# Altium schematic sheet MCU.SchDoc(MCU)
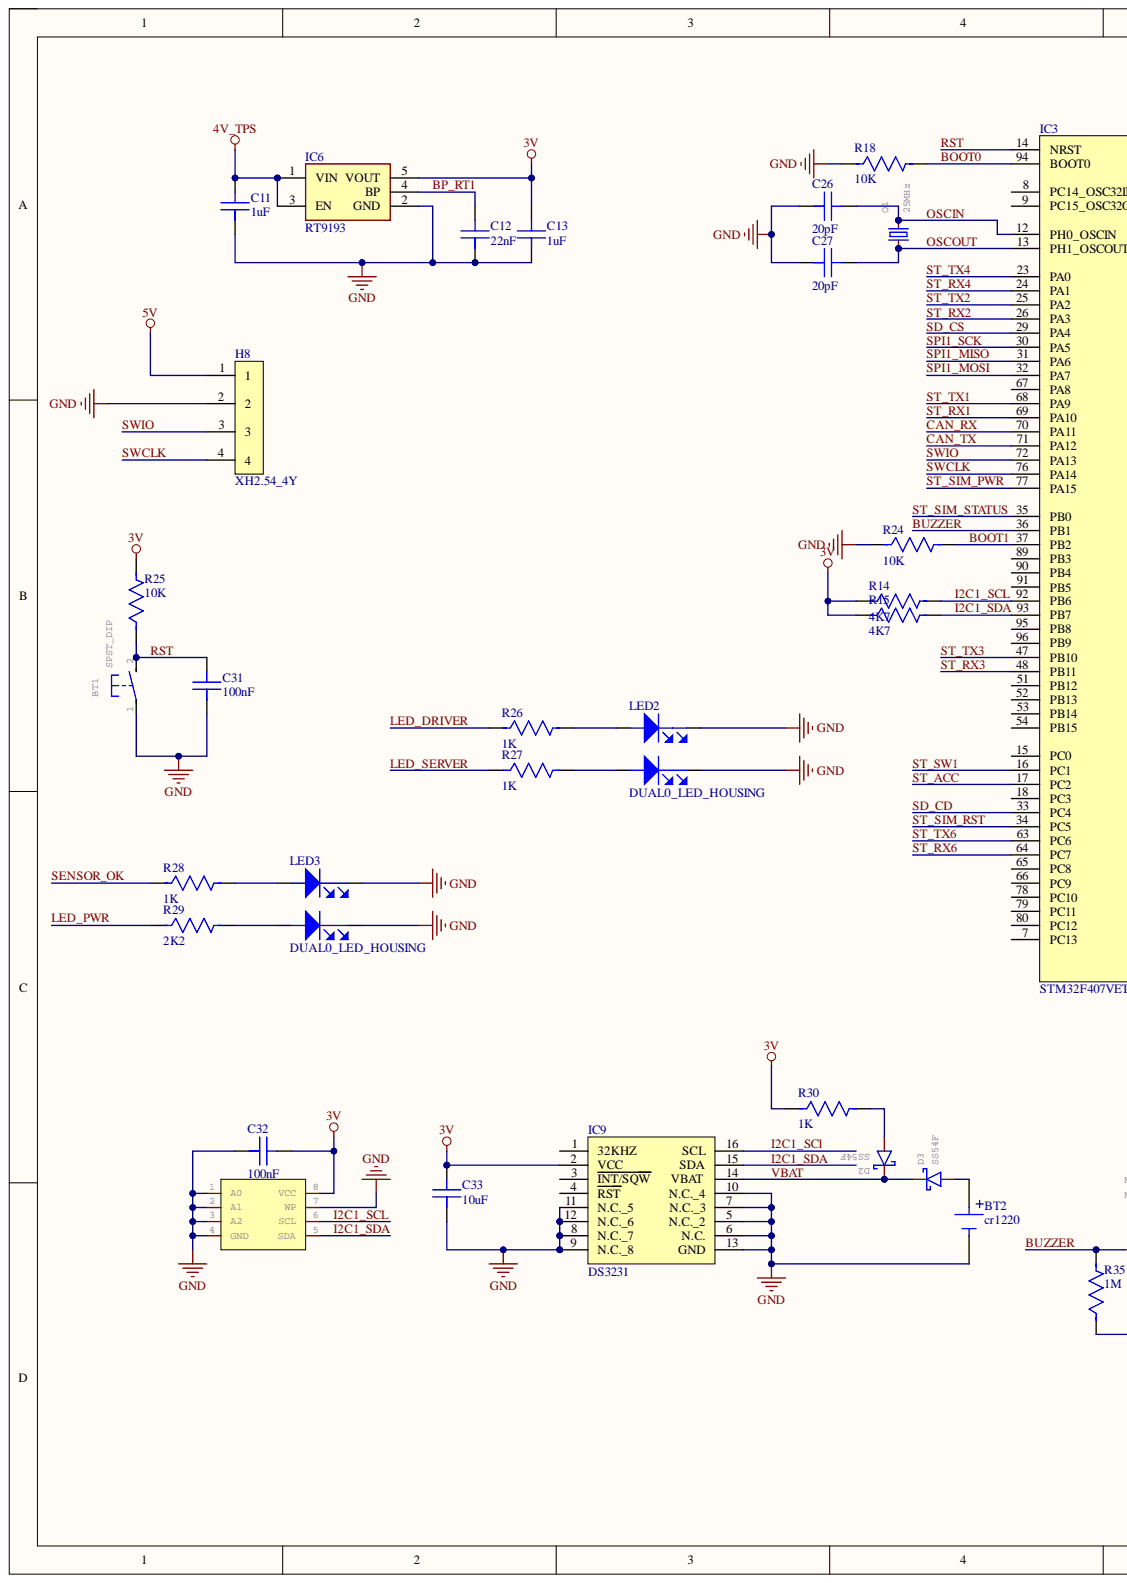
[diagram p0: MCU.SchDoc, left]
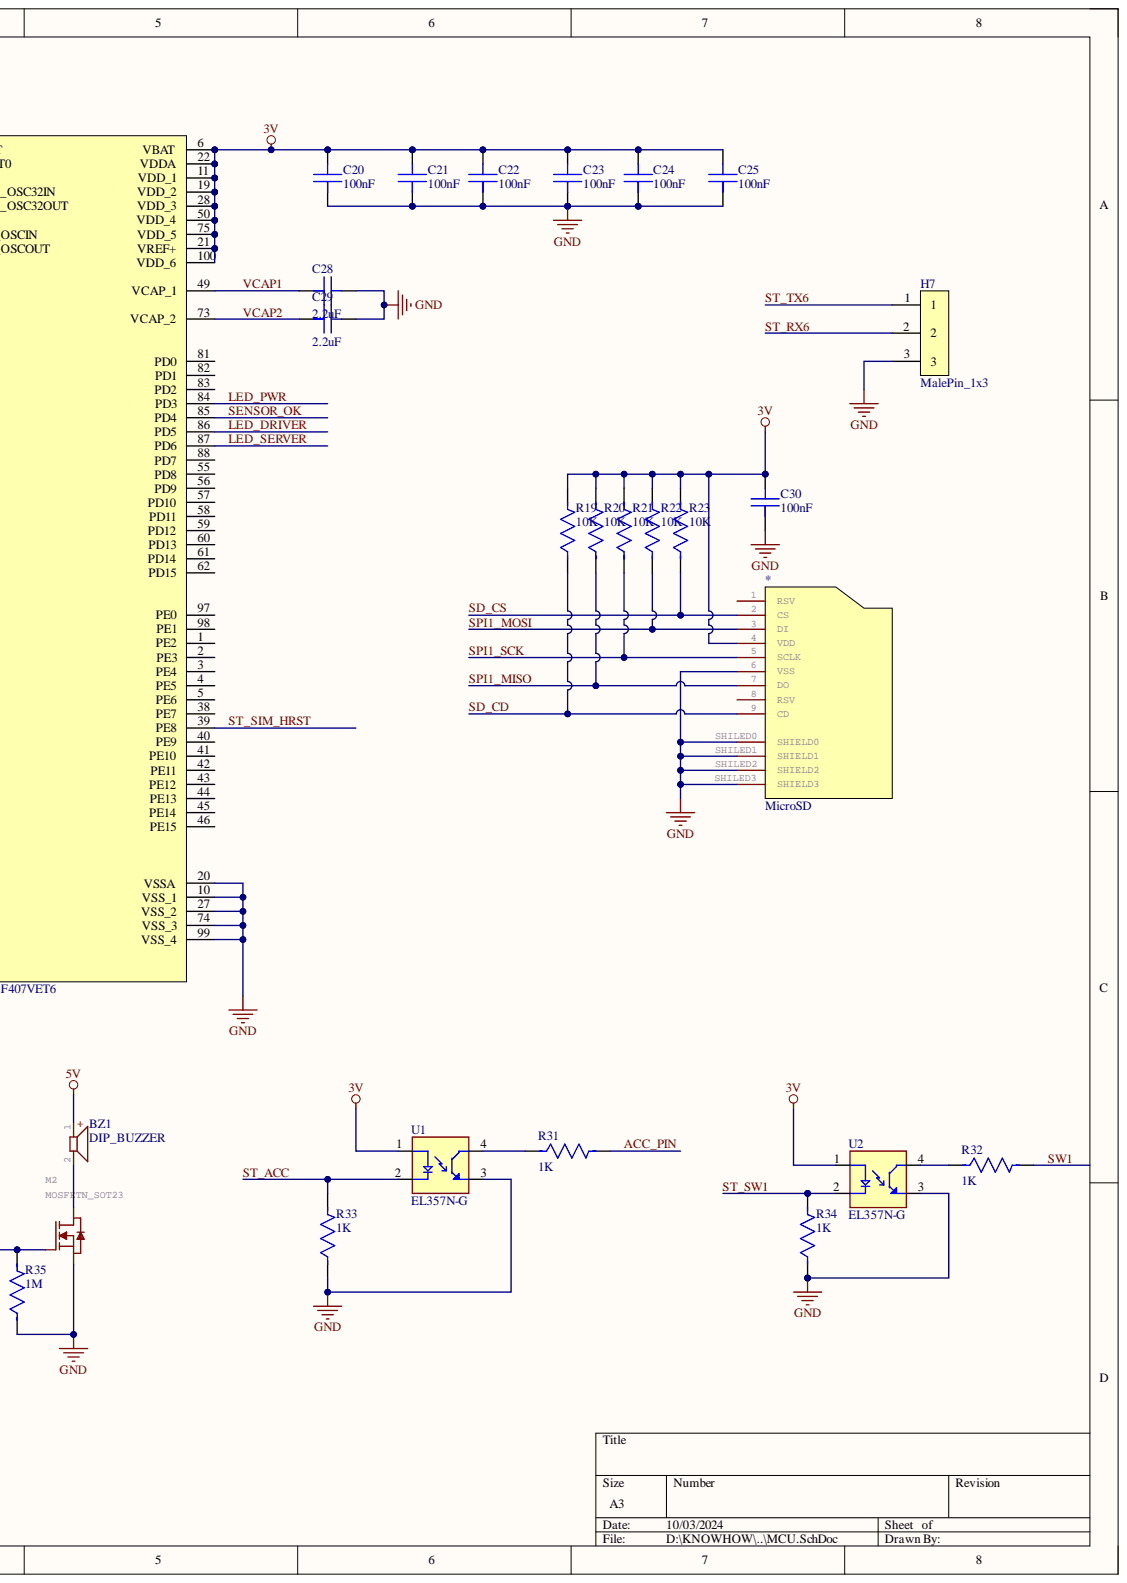
[diagram p1: MCU.SchDoc, right]

## Parts
*  MicroSD  [SD_MiSD-PP-9P-V1]
BT1  SPST_DIP  SymbolName_1: BT1  [SPST_BUTTON_2DIP]
BT2  cr1220  [cr1220]
BZ1  DIP_BUZZER  [DIP_BUZZER]
C11  1uF  [C0603]
C12  22nF  [C0603]
C13  1uF  [C0603]
C20  100nF  [C0603]
C21  100nF  [C0603]
C22  100nF  [C0603]
C23  100nF  [C0603]
C24  100nF  [C0603]
C25  100nF  [C0603]
C26  20pF  [C0603]
C27  20pF  [C0603]
C28  2.2uF  [C0603]
C29  2.2uF  [C0805]
C30  100nF  [C0603]
C31  100nF  [C0603]
C32  100nF  [C0603]
C33  10uF  [C0603]
D2  SS54F  [SMAF]
D3  SS54F  [SMAF]
H7  MalePin_1x3  [MALEPIN_1x3]
H8  XH2.54_4Y  [JST-XH-04-PACKAGE-LONG-PAD]
IC3  STM32F407VET6  [LQFP100]
IC6  RT9193  [SOT-23-5]
IC9  DS3231  [SOP-16]
IC10  24LC0XX  [SOIC-8]  (value not drawn)
LED2  DUAL0_LED_HOUSING  [DUAL LED HOUSING]
LED3  DUAL0_LED_HOUSING  [DUAL LED HOUSING]
M2  MOSFETN_SOT23  [SOT23]
O1  25MHz  [CRYSTAL_5032]
R14  4K7  [R0603]
R15  4K7  [R0603]
R18  10K  [R0603]
R19  10K  [R0603]
R20  10K  [R0603]
R21  10K  [R0603]
R22  10K  [R0603]
R23  10K  [R0603]
R24  10K  [R0603]
R25  10K  [R0603]
R26  1K  [R0603]
R27  1K  [R0603]
R28  1K  [R0603]
R29  2K2  [R0603]
R30  1K  [R0603]
R31  1K  [R0603]
R32  1K  [R0603]
R33  1K  [R0603]
R34  1K  [R0603]
R35  1M  [R0603]
U1  EL357N-G  [OPTO_EL357N-G]
U2  EL357N-G  [OPTO_EL357N-G]

## Nets
3V: *.4(VDD) C13.2 C20.2 C21.2 C22.2 C23.2 C24.2 C25.2 C30.2 C32.2 C33.2 IC3.6(VBAT) IC3.11(VDD_1) IC3.19(VDD_2) IC3.21(VREF+) IC3.22(VDDA) IC3.28(VDD_3) IC3.50(VDD_4) IC3.75(VDD_5) IC3.100(VDD_6) IC6.5(VOUT) IC9.2(VCC) IC10.8(VCC) R14.1 R15.1 R19.2 R20.2 R21.2 R22.2 R23.2 R25.2 R30.1 U1.1 U2.1
4V_TPS: C11.2 IC6.1(VIN) IC6.3(EN)
5V: BZ1.1 H8.1
GND: *.6(VSS) *.SHILED0(SHIELD0) *.SHILED1(SHIELD1) *.SHILED2(SHIELD2) *.SHILED3(SHIELD3) BT1.1 BT2.N C11.1 C12.1 C13.1 C20.1 C21.1 C22.1 C23.1 C24.1 C25.1 C26.1 C27.1 C28.2 C29.2 C30.1 C31.1 C32.1 C33.1 H7.3 H8.2 IC3.10(VSS_1) IC3.20(VSSA) IC3.27(VSS_2) IC3.74(VSS_3) IC3.99(VSS_4) IC6.2(GND) IC9.5(N.C._2) IC9.6(N.C.) IC9.7(N.C._3) IC9.8(N.C._7) IC9.9(N.C._8) IC9.10(N.C._4) IC9.11(N.C._5) IC9.12(N.C._6) IC9.13(GND) IC10.1(A0) IC10.2(A1) IC10.3(A2) IC10.4(GND) IC10.7(WP) LED2.2 LED2.4 LED3.2 LED3.4 M2.2 R18.1 R24.1 R33.1 R34.1 R35.1 U1.3 U2.3
ACC_PIN: R31.2
BOOT0: IC3.94(BOOT0) R18.2
BOOT1: IC3.37(PB2) R24.2
BP_RT1: C12.2 IC6.4(BP)
BUZZER: IC3.36(PB1) M2.1 R35.2
CAN_RX: IC3.70(PA11)
CAN_TX: IC3.71(PA12)
I2C1_SCl: IC3.92(PB6) IC9.16(SCL) IC10.6(SCL) R14.2
I2C1_SDA: IC3.93(PB7) IC9.15(SDA) IC10.5(SDA) R15.2
LED_DRIVER: IC3.86(PD5) R26.1
LED_PWR: IC3.84(PD3) R29.1
LED_SERVER: IC3.87(PD6) R27.1
OSCIN: C26.2 IC3.12(PH0_OSCIN) O1.2
OSCOUT: C27.2 IC3.13(PH1_OSCOUT) O1.1
RST: BT1.2 C31.2 IC3.14(NRST) R25.1
SD_CD: *.9(CD) IC3.33(PC4) R19.1
SD_CS: *.2(CS) IC3.29(PA4) R23.1
SENSOR_OK: IC3.85(PD4) R28.1
SPI1_MISO: *.7(DO) IC3.31(PA6) R20.1
SPI1_MOSI: *.3(DI) IC3.32(PA7) R22.1
SPI1_SCK: *.5(SCLK) IC3.30(PA5) R21.1
ST_ACC: IC3.17(PC2) R33.2 U1.2
ST_RX1: IC3.69(PA10)
ST_RX2: IC3.26(PA3)
ST_RX3: IC3.48(PB11)
ST_RX4: IC3.24(PA1)
ST_RX6: H7.2 IC3.64(PC7)
ST_SIM_HRST: IC3.39(PE8)
ST_SIM_PWR: IC3.77(PA15)
ST_SIM_RST: IC3.34(PC5)
ST_SIM_STATUS: IC3.35(PB0)
ST_SW1: IC3.16(PC1) R34.2 U2.2
ST_TX1: IC3.68(PA9)
ST_TX2: IC3.25(PA2)
ST_TX3: IC3.47(PB10)
ST_TX4: IC3.23(PA0)
ST_TX6: H7.1 IC3.63(PC6)
SW1: R32.2
SWCLK: H8.4 IC3.76(PA14)
SWIO: H8.3 IC3.72(PA13)
VBAT: D2.C D3.C IC9.14(VBAT)
VCAP1: C28.1 IC3.49(VCAP_1)
VCAP2: C29.1 IC3.73(VCAP_2)
NetBT2_P: BT2.P D3.A
NetBZ1_2: BZ1.2 M2.3
NetD2_A: D2.A R30.2
NetLED2_1: LED2.1 R26.2
NetLED2_3: LED2.3 R27.2
NetLED3_1: LED3.1 R28.2
NetLED3_3: LED3.3 R29.2
NetR31_1: R31.1 U1.4
NetR32_1: R32.1 U2.4

Not connected: * 1(RSV) 8(RSV); IC3 1(PE2) 2(PE3) 3(PE4) 4(PE5) 5(PE6) 7(PC13) 8(PC14_OSC32IN) 9(PC15_OSC32OUT) 15(PC0) 18(PC3) 38(PE7) 40(PE9) 41(PE10) 42(PE11) 43(PE12) 44(PE13) 45(PE14) 46(PE15) 51(PB12) 52(PB13) 53(PB14) 54(PB15) 55(PD8) 56(PD9) 57(PD10) 58(PD11) 59(PD12) 60(PD13) 61(PD14) 62(PD15) 65(PC8) 66(PC9) 67(PA8) 78(PC10) 79(PC11) 80(PC12) 81(PD0) 82(PD1) 83(PD2) 88(PD7) 89(PB3) 90(PB4) 91(PB5) 95(PB8) 96(PB9) 97(PE0) 98(PE1); IC9 1(32KHZ) 3(INT/SQW) 4(RST)

## Library data of the parts
BT1 = SPST_DIP: DeviceSetName: BT4; GateName_1: G$1; Component Kind: Standard; LibraryName: Uy_SMD; Package: BT4; Library Name: UY_Schlib.SchLib
BT2 = cr1220: BATTERY HOLDER COIN 12MM SMD; MF: MPD; MP: BC501SM; Availability: Unavailable; Check_prices: https://www.snapeda.com/parts/BC501SM/MPD/view-part/?ref=eda; Component Kind: Standard; Package: None; Price: None; SnapEDA_Link: https://www.snapeda.com/parts/BC501SM/MPD/view-part/?ref=snap; Library Name: UY_Schlib.SchLib
C11-C13 C20-C28 C30-C33 = Capacitor 0603: Component Kind: Standard; Library Name: UY_Schlib.SchLib
C29 = Capacitor 0805: Component Kind: Standard; Library Name: UY_Schlib.SchLib
D2 D3 = SS54F: GateName_1: G$1; SymbolName_1: DIODE_S; Component Kind: Standard; DeviceSetName: SS54F; LibraryName: Uy_SMD; Package: SMAF; Library Name: UY_Schlib.SchLib
IC3 = STM32F407VET6: ARMÂ® CortexÂ®-M4 STM32F4 Microcontroller IC 32-Bit Single-Core 168MHz 512KB (512K x 8) FLASH 100-LQFP (14x14); MF: STMicroelectronics; Availability: In Stock; Check_prices: https://www.snapeda.com/parts/STM32F407VET6/STMicroelectronics/view-part/?ref=eda; Component Kind: Standard; MP: STM32F407VET6; Package: LQFP-100 STMicroelectronics; Price: None; Purchase-URL: https://pricing.snapeda.com/search/part/STM32F407VET6/?ref=eda; SnapEDA_Link: https://www.snapeda.com/parts/STM32F407VET6/STMicroelectronics/view-part/?ref=snap; Library Name: UY_Schlib.SchLib
IC6 = RT9193: Linear Voltage Regulator IC Positive Fixed 1 Output 300mA TSOT-23-5; MF: Richtek USA; MP: RT9193-33GB; Check_prices: https://www.snapeda.com/parts/RT9193-33GB/Richtek+USA+Inc./view-part/?ref=eda; Component Kind: Standard; Digi-Key_Part_Number: 1028-1014-2-ND; Package: SOT-23-5 Richtek; Purchase-URL: https://pricing.snapeda.com/search/part/RT9193-33GB/?ref=eda; SnapEDA_Link: https://www.snapeda.com/parts/RT9193-33GB/Richtek+USA+Inc./view-part/?ref=snap; Library Name: UY_Schlib.SchLib
IC9 = DS3231: Real Time Clock (RTC) IC Clock/Calendar - IÂ²C, 2-Wire Serial 16-SOIC (0.295, 7.50mm Width); MF: Maxim Integrated; MP: DS3231S#; Availability: In Stock; Check_prices: https://www.snapeda.com/parts/DS3231S%23/Maxim+Integrated/view-part/?ref=eda; Component Kind: Standard; Package: SOIC-16 Maxim; Price: None; Purchase-URL: https://pricing.snapeda.com/search/part/DS3231S#/?ref=eda; SnapEDA_Link: https://www.snapeda.com/parts/DS3231S%23/Maxim+Integrated/view-part/?ref=snap; Library Name: UY_Schlib.SchLib
IC10 = 24LC0XX: GateName_1: G$1; Component Kind: Standard; DeviceSetName: 24LC0XX; LibraryName: Uy_SMD; Package: SOIC-8; SymbolName_1: 24LC0XX; Library Name: UY_Schlib.SchLib
M2 = MOSFETN_SOT23: SOT-23; GateName_1: G$1; SymbolName_1: MOSFET_N; Component Kind: Standard; DeviceSetName: MOSFETN; LibraryName: Uy_SMD; Package: SOT23(1); Library Name: UY_Schlib.SchLib
O1 = CRYSTAL_5032: DeviceName: -9B; GateName_1: G$1; SymbolName_1: Q; Component Kind: Standard; DeviceSetName: CRYSTAL_5032; LibraryName: Uy_SMD; Package: 5.0X3.2_2; Library Name: UY_Schlib.SchLib
R14 R15 R18-R35 = Resistor 0603: SMD Resistor 0603; Manufacturer: Yageo; Manufacturer Part Number: RC0603FR-071KL; Max Power Dissipation: 100mW; Resistance Tolerance: 1%; Temperature Coefficient: 100ppm/°C; Voltage Rating: 50V; Case Code (Imperial): 0603; Case Code (Metric): 1608; Case/Package: 0603; Category: Chip SMD Resistors; Component Kind: Standard; Construction: Rectangular; Contact Plating: Tin,Matte; Depth: 0.8mm; Features: Moisture Resistant; Height: 0.45mm; Lead Free: 5697LeadFree; Length: 1.6mm; Max Operating Temperature: 155°C; Military Standard: Not; Min Operating Temperature: -55°C; Number of Terminations: 2; Packaging: Tape and Reel; Power Rating: 100mW; Pricing: 1=0.1, 10=0.018, 50=0.0106, 100=0.0088, 500=0.00592, 1000=0.00505 (USD); Reach SVHC Compliant: No; Resistor Type: Thick Film; RoHS: Yes; RoHS Compliant: Yes; Series: RC; Stock: 18662657; Supplier: Digi-Key; Supplier Part Number: 311-1.00KHRDKR-ND; Technology: THICK FILM; Termination: SMD/SMT; Tolerance: 1%; Library Name: UY_Schlib.SchLib
U1 U2 = EL357N-G: Optoisolator Transistor Output 3750Vrms 1 Channel 4-SOP (2.54mm); MF: Everlight Electronics; Availability: In Stock; Check_prices: https://www.snapeda.com/parts/EL357N-G/Everlight+Electronics+Co+Ltd/view-part/?ref=eda; Component Kind: Standard; MP: EL357N-G; Package: SOP-4 Everlight; Price: None; Purchase-URL: https://pricing.snapeda.com/search/part/EL357N-G/?ref=eda; SnapEDA_Link: https://www.snapeda.com/parts/EL357N-G/Everlight+Electronics+Co+Ltd/view-part/?ref=snap; Library Name: UY_Schlib.SchLib
* = MicroSD: Component Kind: Standard; Library Name: UY_Schlib.SchLib
BZ1 = DIP_BUZZER: Component Kind: Standard; Library Name: UY_Schlib.SchLib
H7 = MalePin_1x3: Component Kind: Standard; Library Name: UY_MalePin2.54.SchLib
H8 = XH2.54_4Y: Component Kind: Standard; Description: XH2.54_4Y; Library Name: UY_JST_XH2.54.SchLib
LED2 LED3 = DUAL0_LED_HOUSING: Component Kind: Standard; Library Name: UY_Schlib.SchLib
